# Altium schematic sheet Sheet1.SchDoc
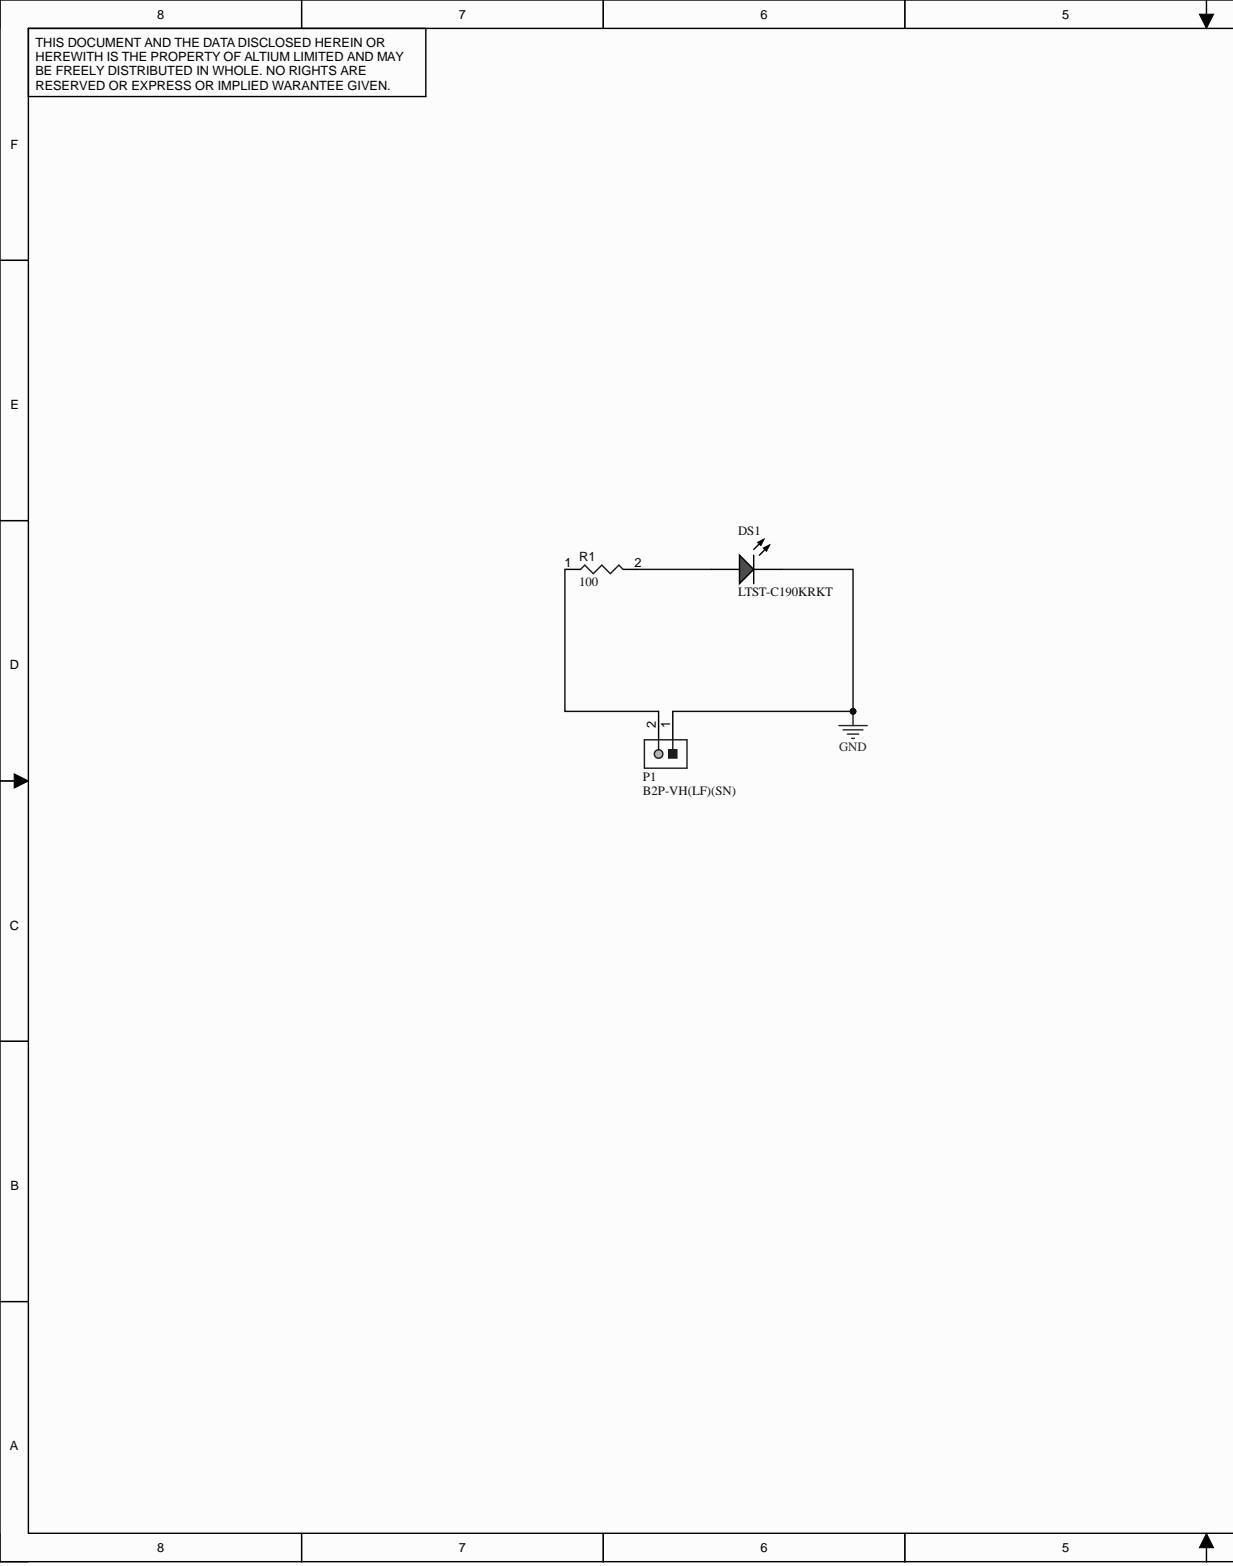
[diagram p0: Sheet1.SchDoc, left]
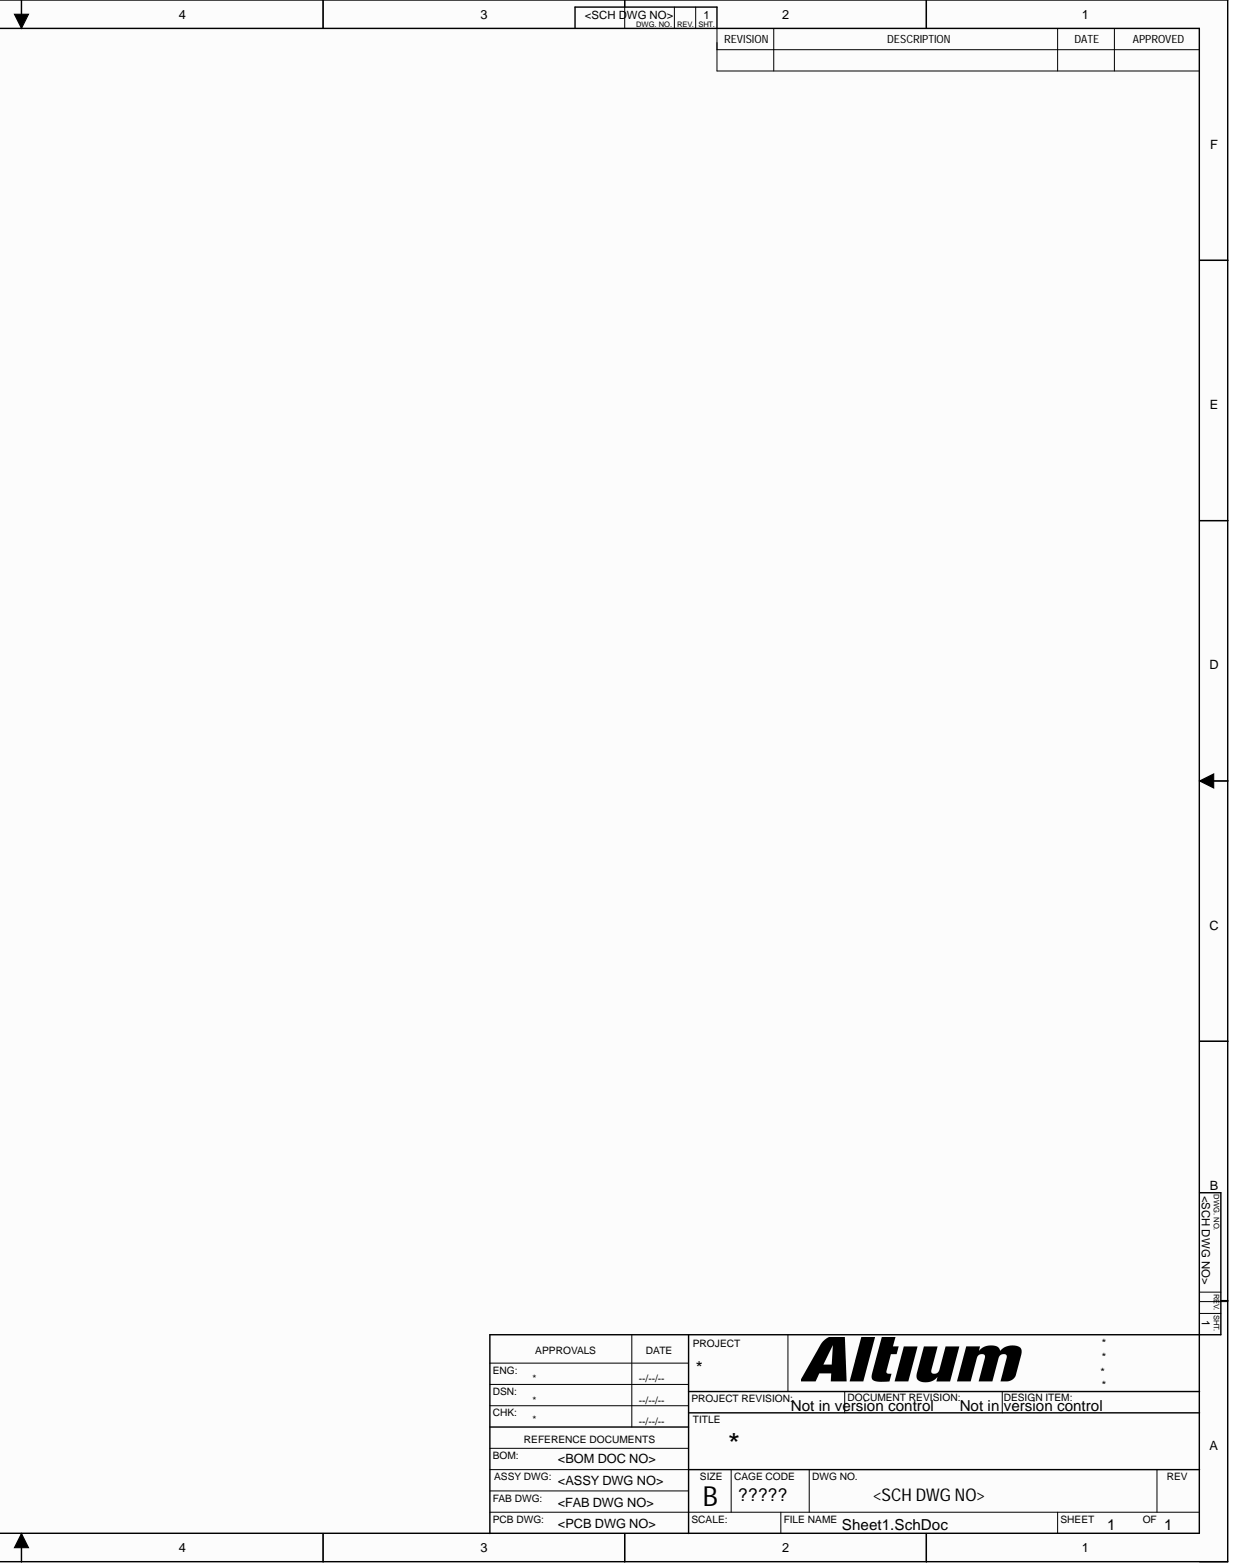
[diagram p1: Sheet1.SchDoc, right]

## Parts
DS1  LTST-C190KRKT  [LTST-C191KRKT_V]
P1  B2P-VH(LF)(SN)  [JST-B2P-VH(LF)(SN)_V]
R1  value 100  [RESC0402(1005)_L]  (hidden comment: Resistor 100R +/-1 % 0402 63 mW)

## Nets
GND: DS1.2 P1.1
NetDS1_1: DS1.1 R1.2
NetP1_2: P1.2 R1.1

## Library data of the parts
DS1 = CMP-007-00024-2: LED Uni-Color Red, 62.5 mW, 25 mA, -30 to 85 degC, 2-Pin SMD, RoHS, Tape and Reel; Manufacturer: Lite-On; Forward Current: 20mA; Forward Voltage: 2V; Lens Style: Diffused; Luminous Intensity: 54mcd; Power: 75mW; Viewing Angle: 130degrees; Case/Package: 0603; Colour: Red; Component Kind: Standard; Datasheet URL: http://optoelectronics.liteon.com/upload/download/DS-22-99-0151/S_110_LTST-C190KRKT.pdf; Datasheet Version: Rev. F, 05/2013; Illumination Colour: Red; Manufacturer URL: http://www.us.liteon.com/; Max Operating Temperature: 85°C; Min Operating Temperature: -55°C; Mounting Technology: SM; Nominal Vf: 2V; Package Description: 2-Pin Surface Mount Device, Body 1.6 x 0.8 mm; Package Quantity: 3000; Package Reference: C191KRKT; Packaging: TapeandReel; Pins: 2; RoHS Compliant: True; Library Name: Ufscar - Universidade Federal de São Carlos
P1 = CMP-002-00025-2: Male Header, Pitch 3.96 mm, 1 x 2 Position, Height 10.9 mm, Tail Length 3.7 mm, -25 to 85 degC, RoHS, Bulk; Manufacturer: JST; Case/Package: B2P-VH(LF)(SN); Component Kind: Standard; Connector Type: Header; Datasheet URL: http://www.jst-mfg.com/product/pdf/eng/eVH.pdf; Datasheet Version: May-16; Gender: Male; Manufacturer URL: http://www.jst.com/home2.html; Max Operating Temperature: 85°C; Min Operating Temperature: -25°C; Mounting Technology: TH; Package Description: Male Header, Pitch 3.96 mm, 1 x 2 Position, Height 10.9 mm, Tail Length 3.7 mm; Package Reference: B2P-VH(LF)(SN); RoHS Compliant: Unknown; Library Name: Ufscar - Universidade Federal de São Carlos
R1 = CMP-009-00167-3: Chip Resistor, 100 Ohms, +/-1 %, 63 mW, -55 to 155 degC, 0402; Power: 63mW; Tolerance: 1%; Case/Package: 0402; Component Kind: Standard; Max Operating Temperature: 155°C; Min Operating Temperature: -55°C; Pins: 2; Library Name: Ufscar - Universidade Federal de São Carlos
